# Altium schematic sheet SD_and_CAN.SchDoc(SD_and_CAN)
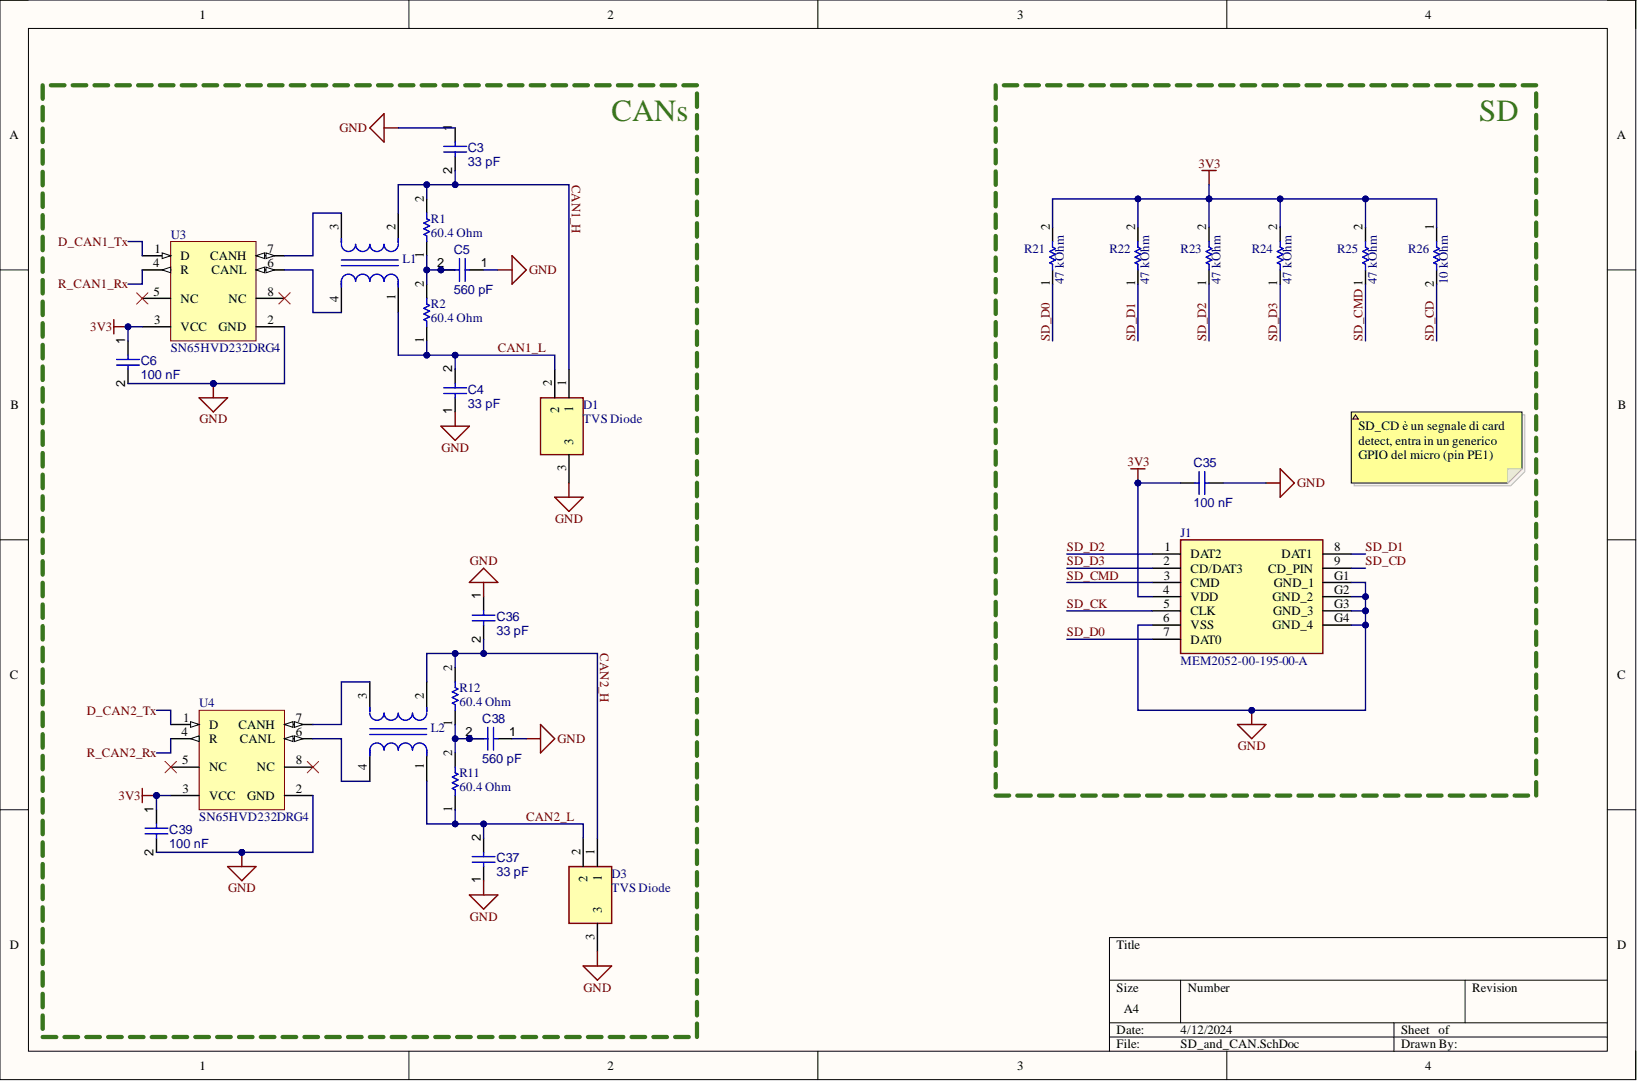
[diagram p0: SD_and_CAN.SchDoc]

## Parts
C3  33 pF
C4  33 pF
C5  560 pF
C6  100 nF
C35  100 nF
C36  33 pF
C37  33 pF
C38  560 pF
C39  100 nF
D1  TVS Diode
D3  TVS Diode
J1  MEM2052-00-195-00-A
L1  ACT45B-101-2P-TL003  (value not drawn)
L2  ACT45B-101-2P-TL003  (value not drawn)
R1  60.4 Ohm
R2  60.4 Ohm
R11  60.4 Ohm
R12  60.4 Ohm
R21  47 kOhm
R22  47 kOhm
R23  47 kOhm
R24  47 kOhm
R25  47 kOhm
R26  10 kOhm
U3  SN65HVD232DRG4  [SN65HVD232]
U4  SN65HVD232DRG4  [SN65HVD232]

## Nets
3V3: C6.1 C35.2 C39.1 J1.4(VDD) R21.2 R22.2 R23.2 R24.2 R25.2 R26.1 U3.3(VCC) U4.3(VCC)
GND: C3.1 C4.1 C5.1 C6.2 C35.1 C36.1 C37.1 C38.1 C39.2 D1.3 D3.3 J1.6(VSS) J1.G1(GND_1) J1.G2(GND_2) J1.G3(GND_3) J1.G4(GND_4) U3.2(GND) U4.2(GND)
CAN1_H: C3.2 D1.1 L1.2 R1.2
CAN1_L: C4.2 D1.2 L1.1 R2.1
CAN2_H: C36.2 D3.1 L2.2 R12.2
CAN2_L: C37.2 D3.2 L2.1 R11.1
D_CAN1_Tx: U3.1(D)
D_CAN2_Tx: U4.1(D)
R_CAN1_Rx: U3.4(R)
R_CAN2_Rx: U4.4(R)
SD_CD: J1.9(CD_PIN) R26.2
SD_CK: J1.5(CLK)
SD_CMD: J1.3(CMD) R25.1
SD_D0: J1.7(DAT0) R21.1
SD_D1: J1.8(DAT1) R22.1
SD_D2: J1.1(DAT2) R23.1
SD_D3: J1.2(CD/DAT3) R24.1
NetC5_2: C5.2 R1.1 R2.2
NetC38_2: C38.2 R11.2 R12.1
NetL1_3: L1.3 U3.7(CANH)
NetL1_4: L1.4 U3.6(CANL)
NetL2_3: L2.3 U4.7(CANH)
NetL2_4: L2.4 U4.6(CANL)

Not connected: U3 5(NC) 8(NC); U4 5(NC) 8(NC)

## Library data of the parts
C3 C4 C36 C37 = CMP-001-00085-2: VJ0805A330JXACW1BC; Tech: SMD; Component Kind: Standard; ComponentLink1Description: Website; ComponentLink1URL: https://www.mouser.it/ProductDetail/Vishay-Vitramon/VJ0805A330JXACW1BC?qs=5Rws4bj8rAGiHl%252BySR4oOA%3D%3D; Package: 0805; Library Name: EMEA- Squadra Corse PoliTO-  Politecnico di Torino
C5 C38 = CMP-001-00085-2: VJ0805Y561JXXPW1BC; Tech: SMD; Component Kind: Standard; ComponentLink1Description: Website; ComponentLink1URL: https://www.mouser.it/ProductDetail/Vishay-Vitramon/VJ0805Y561JXXPW1BC?qs=RHlxhb6sQsJIXs1Iyq971g%3D%3D; Package: 0805; Library Name: EMEA- Squadra Corse PoliTO-  Politecnico di Torino
C6 C39 = CMP-001-00085-2: VJ0805Y104MXATW1BC; Tech: SMD; Component Kind: Standard; ComponentLink1Description: Website; ComponentLink1URL: https://www.mouser.it/ProductDetail/Vishay-Vitramon/VJ0805Y104KBABE31?qs=TLaLXeh6c70yB%252BsdRZoYCQ%3D%3D; Package: 0805; Library Name: EMEA- Squadra Corse PoliTO-  Politecnico di Torino
C35 = CMP-001-00085-5: VJ0805Y104MXATW1BC; Tech: SMD; Component Kind: Standard; ComponentLink1Description: Website; Package: 0805; Library Name: EMEA- Squadra Corse PoliTO-  Politecnico di Torino
D1 D3 = CMP-030-000036-3: ESDCAN24-2BLY; Tech: SMD; Component Kind: Standard; Package: SOT-23-3; Library Name: EMEA- Squadra Corse PoliTO-  Politecnico di Torino
J1 = CMP-040-000020-1: 9 (8 + 1) Position Card Connector Secure Digital - microSD Surface Mount; Manufacturer_Name: GCT (GLOBAL CONNECTOR TECHNOLOGY); Component Kind: Standard; Datasheet Link: https://gct.co/files/drawings/MEM2052.pdf; Design Item ID: MEM2052-00-195-00-A; Height: 2.2mm; Manufacturer_Part_Number: MEM2052-00-195-00-A; Mouser Part Number: 640-MEM20520019500A; Mouser Price/Stock: https://www.mouser.co.uk/ProductDetail/GCT/MEM2052-00-195-00-A?qs=Jm2GQyTW%2Fbh7Ze6LKWKWwA%3D%3D; Source: SamacSys.SchLib; Library Name: EMEA- Squadra Corse PoliTO-  Politecnico di Torino
L1 L2 = CMP-08256-000007-1: CMC 100UH 150MA 2LN SMD AEC-Q200; Manufacturer: TDK; Automotive Grade: Grade 0; Impedance At Frequency: 5800Ω @ 10MHz; Rms Current: 0.15A; Series Resistance: 2Ω; Test Frequency: 100kHz; Tolerance: +50/-30%; Automotive: Yes; Category: Ind; Component Kind: Standard; ComponentLink2Description: Datasheet; ComponentLink2URL: https://product.tdk.com/info/en/catalog/datasheets/cmf_automotive_signal_act45b_en.pdf; Composition: Wirewound; Device Class L1: Passive Components; Device Class L2: EMI / RFI Components; Device Class L3: Common Mode Chokes; Digikey Description: CMC 100UH 150MA 2LN SMD AEC-Q200; Height: 3mm; Inductance: 100uH; Lead Free: Yes; Library Name: Altium Content Vault; Manufacturer Part Number: ACT45B-101-2P-TL003; Mouser Description: Common Mode Chokes / Filters 5000ohms 100uH 150mA CAN-BUS AEC-Q200; Octopart: https://octopart.com/act45b-101-2p-tl003-tdk-11353565; Package: ACT45B; RoHS: TRUE; Temperature: -40°C to +150°C; Temperature Range High: +150°C; Temperature Range Low: -40°C; Value: 100uH
R1 R2 R11 R12 = CMP-009-00179-4: CRCW060360R4FKEA; Tech: SMD; Component Kind: Standard; ComponentLink1Description: Website; ComponentLink1URL: https://www.mouser.it/ProductDetail/Vishay-Dale/CRCW060360R4FKEA?qs=6fyMJ9%2FiJ9KMVX8MBWVfDg%3D%3D; Package: 0603; Library Name: EMEA- Squadra Corse PoliTO-  Politecnico di Torino
R21-R25 = CMP-00010-3: CRCW060347K0FKEAHP; Component Kind: Standard; Library Name: EMEA- Squadra Corse PoliTO-  Politecnico di Torino
R26 = CMP-00010-3: CRCW060310K0FKEA; Component Kind: Standard; Library Name: EMEA- Squadra Corse PoliTO-  Politecnico di Torino
U3 U4 = CMP-0315-00080-1: 3.3 V Can Transceiver, 17 mA, -40 to 85 degC, 8-pin SOIC (D), Green (RoHS & no Sb/Br); Manufacturer: Texas Instruments; Drivers Per Package: 1; ICC(Max)(mA): 17; Rating: Catalog; Supply Voltage(s)(V): 3.3; Transient Pulse Protection(V): -25 to 25; Code_JEDEC: MS-012AA; Component Kind: Standard; ComponentLink1Description: Datasheet; ComponentLink1URL: http://www.ti.com/general/docs/lit/getliterature.tsp?genericPartNumber=SN65HVD232&fileType=pdf; DatasheetVersion: SLOS346K; Eco-Plan: Green (RoHS & no Sb/Br); Isolated: No; Library Name: Altium Content Vault; Mounting Technology: Surface Mount; PackageDescription: 8-Pin Plastic Small Outline Integrated Circuit, 4.9 x 3.9 mm, Pitch 1.27 mm Pitch; PackageReference: D8; PackageVersion: revM, Jun-2011; PartNumber: SN65HVD232DRG4; Receivers Per Package: 1; SubFamily: CAN
